# Altium schematic sheet Power_Board.SchDoc(Power_Board)
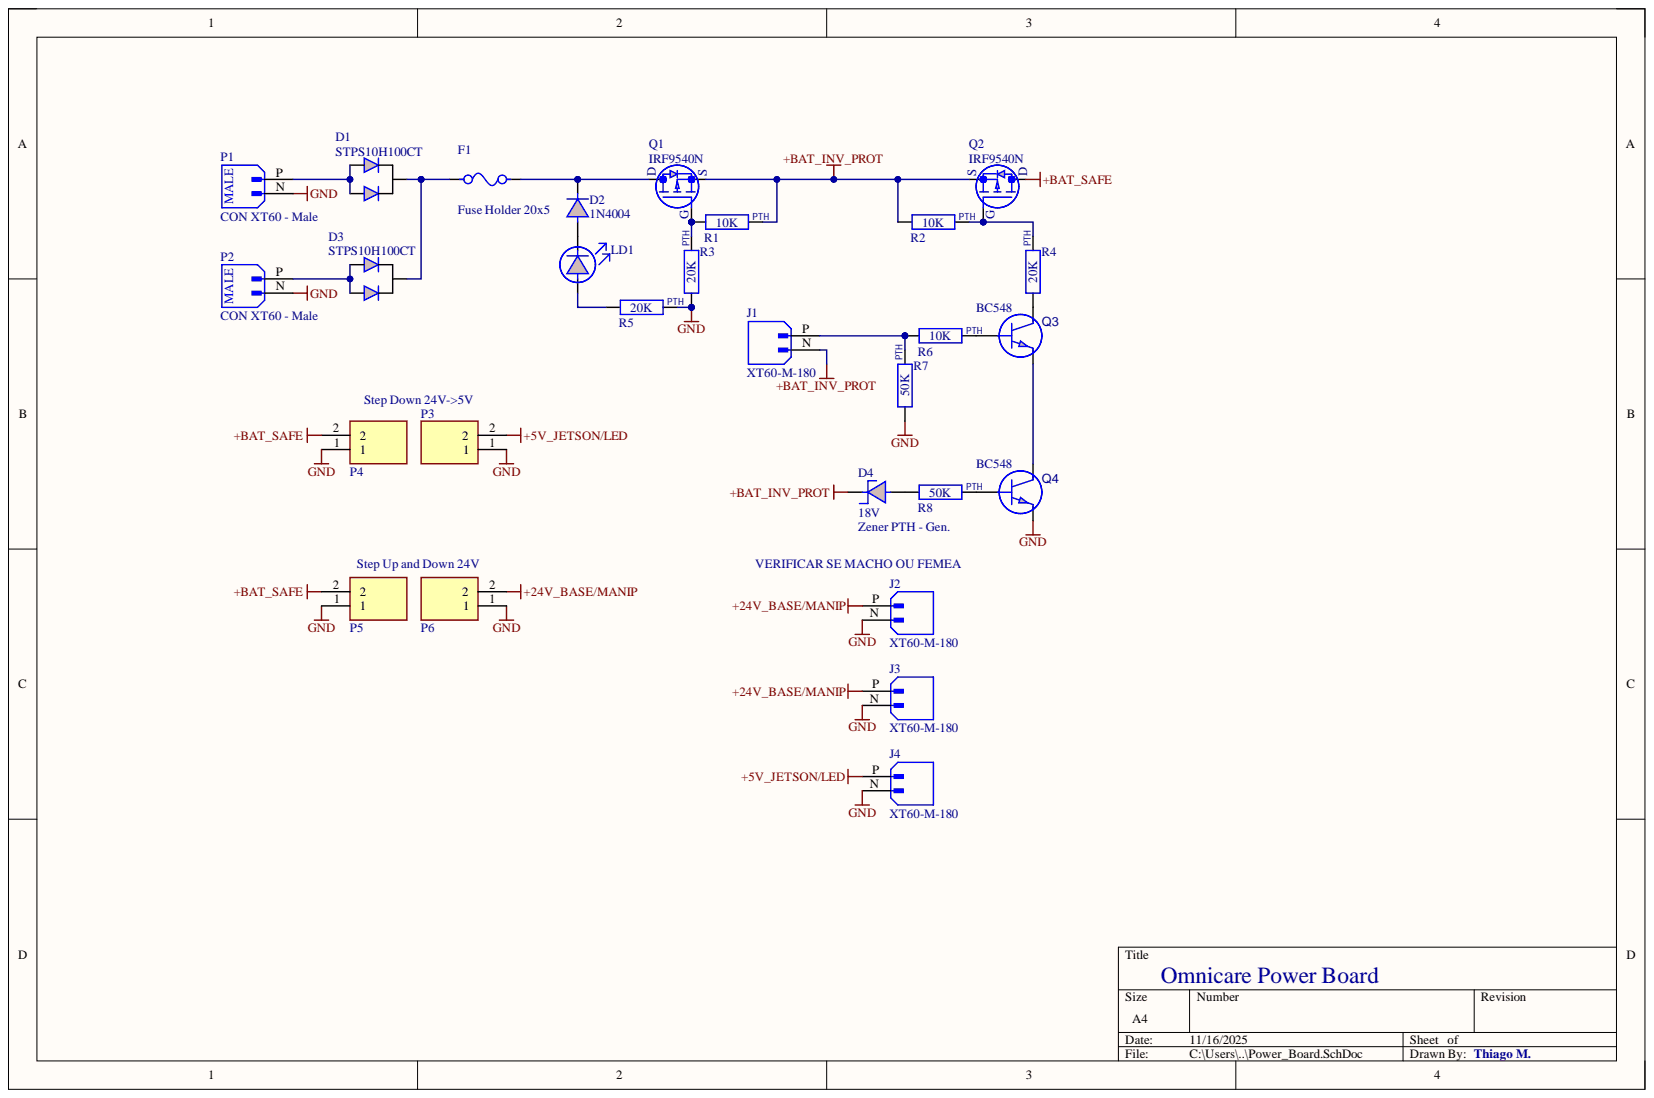
[diagram p0: Power_Board.SchDoc]

## Parts
D1  STPS10H100CT  [TO-220AB]
D2  1N4004  [DO41]
D3  STPS10H100CT  [TO-220AB]
D4  Zener PTH - Gen.  value 18V  [DO41 - Zener]
F1  Fuse Holder 20x5  [FUSE HOLDER 20x5]
J1  XT60-M-180  [AMASS_XT60-M]
J2  XT60-M-180  [AMASS_XT60-M]
J3  XT60-M-180  [AMASS_XT60-M]
J4  XT60-M-180  [AMASS_XT60-M]
LD1  LED PTH - Gen.  [DO41]  (value not drawn)
P1  CON XT60 - Male  [CON XT60 MALE]
P2  CON XT60 - Male  [CON XT60 MALE]
P3  CON KF2 EDGRC-5.08-02P  [BORNE 5.08 RA 2P]  (value not drawn)
P4  CON KF2 EDGRC-5.08-02P  [BORNE 5.08 RA 2P]  (value not drawn)
P5  CON KF2 EDGRC-5.08-02P  [BORNE 5.08 RA 2P]  (value not drawn)
P6  CON KF2 EDGRC-5.08-02P  [BORNE 5.08 RA 2P]  (value not drawn)
Q1  IRF9540N  [TO-220AB]
Q2  IRF9540N  [TO-220AB]
Q3  BC548  [TO-92 LPM]
Q4  BC548  [TO-92 LPM]
R1  10K  [RES PTH]
R2  10K  [RES PTH]
R3  20K  [RES PTH]
R4  20K  [RES PTH]
R5  20K  [RES PTH]
R6  10K  [RES PTH]
R7  50K  [RES PTH]
R8  50K  [RES PTH]

## Nets
+5V_JETSON/LED: J4.P P3.2
+24V_BASE/MANIP: J2.P J3.P P6.2
+BAT_INV_PROT: D4.1 J1.N Q1.3 Q2.3 R1.1 R2.2
+BAT_SAFE: P4.2 P5.2 Q2.2
GND: J2.N J3.N J4.N P1.N P2.N P3.1 P4.1 P5.1 P6.1 Q4.3 R3.2 R5.1 R7.2
NetD1_1: D1.1 D1.3 P1.P
NetD1_2: D1.2 D3.2 F1.1
NetD2_1: D2.1 F1.2 Q1.2
NetD2_2: D2.2 LD1.1
NetD3_1: D3.1 D3.3 P2.P
NetD4_2: D4.2 R8.2
NetJ1_P: J1.P R6.2 R7.1
NetLD1_2: LD1.2 R5.2
NetQ1_1: Q1.1 R1.2 R3.1
NetQ2_1: Q2.1 R2.1 R4.1
NetQ3_1: Q3.1 R4.2
NetQ3_2: Q3.2 R6.1
NetQ3_3: Q3.3 Q4.1
NetQ4_2: Q4.2 R8.1

## Library data of the parts
D1 D3 = STPS10H100CT: Diodo Schottky de 2x5A e 100V de corrente reversa; Component Kind: Standard; ComponentLink1Description: New link; ComponentLink1URL: https://www.acheicomponentes.com.br/diodo/diodo-retificador-stps10h100ct-100v-10a-to-220-pth; Library Name: My_Lib.IntLib
D2 = 1N4004: DIODE - PTH 400V 1A; Vf: 1.1V; Component Kind: Standard; If: 1A; Library Name: My_Lib.IntLib; Vr: 400V
D4 = Zener PTH - Gen.: DIODE - PTH Zener generic; Component Kind: Standard; Library Name: My_Lib.IntLib
J1-J4 = CMP-004-000000-5: Plug; DC supply; XT60; male; PIN: 2; for cable; soldered; 30A; 500V; MF: AMASS; MP: XT60-M; Check_prices: https://www.snapeda.com/parts/XT60-M/AMASS/view-part/?ref=eda; Component Kind: Standard; Component Type: Standard; Design Item ID: XT60-M; Package: Package; Pin Count: 2; SnapEDA_Link: https://www.snapeda.com/parts/XT60-M/AMASS/view-part/?ref=snap; Source: XT60-M.SchLib; Library Name: TCC
LD1 = LED PTH - Gen.: Generic PTH Led; Component Kind: Standard; Library Name: My_Lib.IntLib
P3-P6 = CON KF2 EDGRC-5.08-02P: CONECTOR - Borne 2 Vias Macho Passo 5.08mm 90º KF2 EDGRC-5.08-02P Fechado.; Component Kind: Standard; ComponentLink1Description: URL; ComponentLink1URL: https://www.acheicomponentes.com.br/conector/conector-borne-2-vias-macho-passo-5-08mm-90o-kf2-edgrc-5-08-02p-fechado; Library Name: My_Lib.IntLib
Q1 Q2 = IRF9540N: Mosfet PMOS PTH - 23A Id; Id: -22A; Vgs Max: 20V; Vth: -10V; Component Kind: Standard; Library Name: My_Lib.IntLib
Q3 Q4 = BC548: TRANSISTOR -  TBJ NPN; Component Kind: Standard; Library Name: My_Lib.IntLib
R1-R8 = RES PTH - Gen.: Generic PTH resistor for general purpose; Component Kind: Standard; Library Name: My_Lib.IntLib
  R1 R2 R6: value: 10K
  R3-R5: value: 20K
  R7 R8: value: 50K
F1 = Fuse Holder 20x5: Component Kind: Standard; Library Name: My_Lib.IntLib
P1 P2 = CON XT60 - Male: Component Kind: Standard; Library Name: My_Lib.IntLib
